# Altium schematic sheet DCDC_RPI.SchDoc(DCDC_RPI)
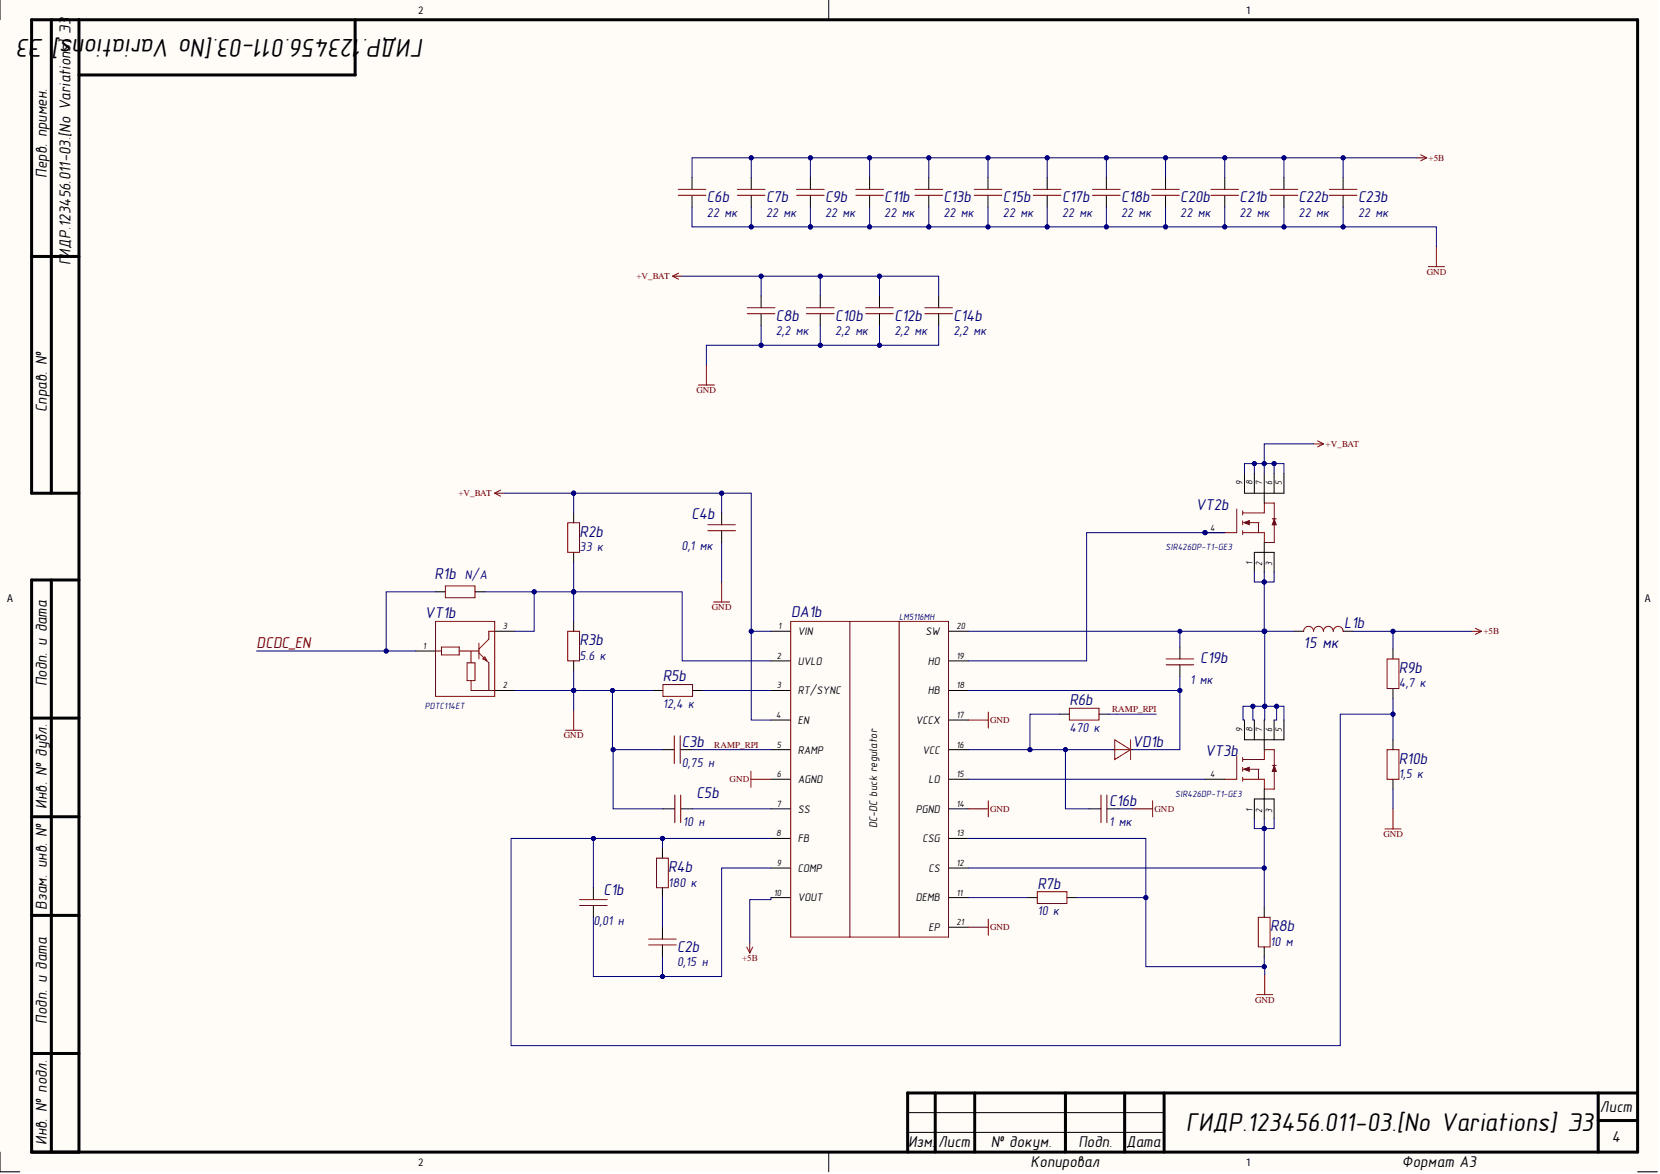
[diagram p0: DCDC_RPI.SchDoc]

## Parts
C1b  value 0,01 н  Capacity (nF): 0,01  [0603C]  (hidden comment: Capacitor)
C2b  value 0,15 н  Capacity (nF): 0,15  [0603C]  (hidden comment: Capacitor)
C3b  value 0,75 н  Capacity (nF): 0,75  [0603C]  (hidden comment: Capacitor)
C4b  value 0,1 мк  Tolerance: 0,1  [0603C]  (hidden comment: Capacitor)
C5b  value 10 н  [0603C]  (hidden comment: Capacitor)
C6b  value 22 мк  [1210C]  (hidden comment: Capacitor)
C7b  value 22 мк  [1210C]  (hidden comment: Capacitor)
C8b  Capacitor  [1206C]  (value not drawn)
C9b  value 22 мк  [1210C]  (hidden comment: Capacitor)
C10b  value 2,2 мк  [1206C]  (hidden comment: Capacitor)
C11b  value 22 мк  [1210C]  (hidden comment: Capacitor)
C12b  value 2,2 мк  [1206C]  (hidden comment: Capacitor)
C13b  value 22 мк  [1210C]  (hidden comment: Capacitor)
C14b  value 2,2 мк  [1206C]  (hidden comment: Capacitor)
C15b  value 22 мк  [1210C]  (hidden comment: Capacitor)
C16b  value 1 мк  [0603C]  (hidden comment: Capacitor)
C17b  value 22 мк  [1210C]  (hidden comment: Capacitor)
C18b  value 22 мк  [1210C]  (hidden comment: Capacitor)
C19b  value 1 мк  [0603C]  (hidden comment: Capacitor)
C20b  value 22 мк  [1210C]  (hidden comment: Capacitor)
C21b  value 22 мк  [1210C]  (hidden comment: Capacitor)
C22b  value 22 мк  [1210C]  (hidden comment: Capacitor)
C23b  value 22 мк  [1210C]  (hidden comment: Capacitor)
DA1b  LM5116MH  [HTSSOP-20]
L1b  value 15 мк  [SPRH127]  (hidden comment: CDSPRH127-150M)
R1b  Resistor  [0603R]  (value not drawn)
R2b  value 33 к  [0603R]  (hidden comment: Resistor)
R3b  value 5.6 к  [0603R]  (hidden comment: Resistor)
R4b  value 180 к  [0603R]  (hidden comment: Resistor)
R5b  value 12,4 к  [0603R]  (hidden comment: Resistor)
R6b  value 470 к  [0603R]  (hidden comment: Resistor)
R7b  value 10 к  [0603R]  (hidden comment: Resistor)
R8b  value 10 м  [1210R]  (hidden comment: Resistor)
R9b  value 4,7 к  [0603R]  (hidden comment: Resistor)
R10b  value 1,5 к  [0603R]  (hidden comment: Resistor)
VD1b  ES1D-E3/61T  [SMA]  (value not drawn)
VT1b  PDTC114ET  [SOT23-3]
VT2b  SIR426DP-T1-GE3  [PowerPAK_SO-8]
VT3b  SIR426DP-T1-GE3  [PowerPAK_SO-8]

## Nets
+5В: C6b.2 C7b.2 C9b.2 C11b.2 C13b.2 C15b.2 C17b.2 C18b.2 C20b.2 C21b.2 C22b.2 C23b.2 DA1b.10(VOUT) L1b.2 R9b.2
+V_BAT: C4b.2 C8b.2 C10b.2 C12b.2 C14b.2 DA1b.1(VIN) DA1b.4(EN) R2b.2 VT2b.5 VT2b.6 VT2b.7 VT2b.8 VT2b.9
GND: C3b.1 C4b.1 C5b.1 C6b.1 C7b.1 C8b.1 C9b.1 C10b.1 C11b.1 C12b.1 C13b.1 C14b.1 C15b.1 C16b.2 C17b.1 C18b.1 C20b.1 C21b.1 C22b.1 C23b.1 DA1b.6(AGND) DA1b.13(CSG) DA1b.14(PGND) DA1b.17(VCCX) DA1b.21(EP) R3b.1 R5b.1 R7b.2 R8b.1 R10b.1 VT1b.2
DCDC_EN: R1b.1 VT1b.1
RAMP_RPI: C3b.2 DA1b.5(RAMP) R6b.2
NetC1b_1: C1b.1 C2b.1 DA1b.9(COMP)
NetC1b_2: C1b.2 DA1b.8(FB) R4b.2 R9b.1 R10b.2
NetC2b_2: C2b.2 R4b.1
NetC5b_2: C5b.2 DA1b.7(SS)
NetC16b_1: C16b.1 DA1b.16(VCC) R6b.1 VD1b.1
NetC19b_1: C19b.1 DA1b.18(HB) VD1b.2
NetC19b_2: C19b.2 DA1b.20(SW) L1b.1 VT2b.1 VT2b.2 VT2b.3 VT3b.5 VT3b.6 VT3b.7 VT3b.8 VT3b.9
NetDA1b_2: DA1b.2(UVLO) R1b.2 R2b.1 R3b.2 VT1b.3
NetDA1b_3: DA1b.3(RT/SYNC) R5b.2
NetDA1b_11: DA1b.11(DEMB) R7b.1
NetDA1b_12: DA1b.12(CS) R8b.2 VT3b.1 VT3b.2 VT3b.3
NetDA1b_15: DA1b.15(LO) VT3b.4
NetDA1b_19: DA1b.19(HO) VT2b.4

## Library data of the parts
C1b = Capacitor_0,01n_10_50V_X7R_0603C: Конденсатор; Database Table Name: Capacitors_ceramic$; Dielectric: X7R; Library Path2: Schematic\Capacitor.SchLib; Tolerance: 0,1; Voltage (V): 50; Component Kind: Standard; Library Name: Hydrolib.DbLib; Part Number: Capacitor_0,01n_10_50V_X7R_0603C
C2b = Capacitor_0,15n_10_50V_X7R_0603C: Конденсатор; Database Table Name: Capacitors_ceramic$; Dielectric: X7R; Library Path2: Schematic\Capacitor.SchLib; Tolerance: 0,1; Voltage (V): 50; Component Kind: Standard; Library Name: Hydrolib.DbLib; Part Number: Capacitor_0,15n_10_50V_X7R_0603C
C3b = Capacitor_0,75n_10_50V_X7R_0603C: Конденсатор; Database Table Name: Capacitors_ceramic$; Dielectric: X7R; Library Path2: Schematic\Capacitor.SchLib; Tolerance: 0,1; Voltage (V): 50; Component Kind: Standard; Library Name: Hydrolib.DbLib; Part Number: Capacitor_0,75n_10_50V_X7R_0603C
C4b = Capacitor_0,1u_10_50V_X7R_0603C: Конденсатор; Capacity (nF): 100; Database Table Name: Capacitors_ceramic$; Dielectric: X7R; Library Path2: Schematic\Capacitor.SchLib; Voltage (V): 50; Component Kind: Standard; Library Name: Hydrolib.DbLib; Part Number: Capacitor_0,1u_10_50V_X7R_0603C
C5b = Capacitor_10n_10_50V_X7R_0603C: Конденсатор; Capacity (nF): 10; Database Table Name: Capacitors_ceramic$; Dielectric: X7R; Library Path2: Schematic\Capacitor.SchLib; Tolerance: 0,1; Voltage (V): 50; Component Kind: Standard; Library Name: Hydrolib.DbLib; Part Number: Capacitor_10n_10_50V_X7R_0603C
C6b = Capacitor_22u_10_25V_X7R_1210C: Конденсатор; Capacity (nF): 22000; Database Table Name: Capacitors_ceramic$; Dielectric: X7R; Library Path2: Schematic\Capacitor.SchLib; Tolerance: 0,1; Value: 22 мк; Voltage (V): 25; Component Kind: Standard; Library Name: Hydrolib.DbLib; Part Number: Capacitor_22u_10_25V_X7R_1210C
C7b C9b C11b C13b C15b C17b C18b C20b C21b C22b C23b = Capacitor_22u_10_25V_X7R_1210C: Конденсатор; Capacity (nF): 22000; Database Table Name: Capacitors_ceramic$; Dielectric: X7R; Library Path2: Schematic\Capacitor.SchLib; Tolerance: 0,1; Voltage (V): 25; Component Kind: Standard; Library Name: Hydrolib.DbLib; Part Number: Capacitor_22u_10_25V_X7R_1210C
C8b = Capacitor_2,2u_10_50V_X7R_1206C: Конденсатор; Capacity (nF): 2200; Database Table Name: Capacitors_ceramic$; Dielectric: X7R; Library Path2: Schematic\Capacitor.SchLib; Tolerance: 0,1; Value: 2,2 мк; Voltage (V): 50; Component Kind: Standard; Library Name: Hydrolib.DbLib; Part Number: Capacitor_2,2u_10_50V_X7R_1206C
C10b C12b C14b = Capacitor_2,2u_10_50V_X7R_1206C: Конденсатор; Capacity (nF): 2200; Database Table Name: Capacitors_ceramic$; Dielectric: X7R; Library Path2: Schematic\Capacitor.SchLib; Tolerance: 0,1; Voltage (V): 50; Component Kind: Standard; Library Name: Hydrolib.DbLib; Part Number: Capacitor_2,2u_10_50V_X7R_1206C
C16b C19b = Capacitor_1u_10_50V_X7R_0603C: Конденсатор; Capacity (nF): 1000; Database Table Name: Capacitors_ceramic$; Dielectric: X7R; Library Path2: Schematic\Capacitor.SchLib; Tolerance: 0,1; Voltage (V): 50; Component Kind: Standard; Library Name: Hydrolib.DbLib; Part Number: Capacitor_1u_10_50V_X7R_0603C
DA1b = LM5116MH: dc-dc понижающий adj 6-100v; Manufacturer_Part_Number: LM5116MH/NOPB; Database Table Name: Chips$; Component Kind: Standard; Library Name: Hydrolib.DbLib; Part Number: LM5116MH
L1b = CDSPRH127-150M: Индуктивность на 15 мкГн, номинальный ток 8,4 А; Current (A): 8,4; Database Table Name: Inductance$; Inductance (μH): 15; Component Kind: Standard; Library Name: Hydrolib.DbLib; Part Number: CDSPRH127-150M
R1b = res_0603_N/A: посадочное место 0603 под перемычку; Database Table Name: Resistors$; Tolerance: 1%; Component Kind: Standard; Library Name: Hydrolib.DbLib; Part Number: res_0603_N/A; Value: N/A
R2b = Resistor_33k_1_0603: Резистор номиналом 33 кОм и точностью 1%; Database Table Name: Resistors$; Component Kind: Standard; Library Name: Hydrolib.DbLib; Part Number: Resistor_33k_1_0603; Tolerance: 1%
R3b = Resistor_2.7k_1_0603: Резистор номиналом 2,7 кОм и точностью 1%; Database Table Name: Resistors$; Component Kind: Standard; Library Name: Hydrolib.DbLib; Part Number: Resistor_2.7k_1_0603; Tolerance: 1%
R4b = Resistor_180k_1_0603: Резистор номиналом 180 кОм и точностью 1%; Database Table Name: Resistors$; Component Kind: Standard; Library Name: Hydrolib.DbLib; Part Number: Resistor_180k_1_0603; Tolerance: 1%
R5b = Resistor_12.4k_1_0603: Резистор номиналом 12,4 кОм и точностью 1%; Database Table Name: Resistors$; Component Kind: Standard; Library Name: Hydrolib.DbLib; Part Number: Resistor_12.4k_1_0603; Tolerance: 1%
R6b = Resistor_470k_1_0603: Резистор номиналом 470 кОм и точностью 1%; Database Table Name: Resistors$; Component Kind: Standard; Library Name: Hydrolib.DbLib; Part Number: Resistor_470k_1_0603; Tolerance: 1%
R7b = Resistor_10k_1_0603: Резистор номиналом 10 кОм и точностью 1%; Database Table Name: Resistors$; Component Kind: Standard; Library Name: Hydrolib.DbLib; Part Number: Resistor_10k_1_0603; Tolerance: 1%
R8b = RL1210FR-070R01L: Резистор номиналом 10 мОм, точностью 1%, 0.5Вт; Database Table Name: Resistors$; Component Kind: Standard; Library Name: Hydrolib.DbLib; Part Number: RL1210FR-070R01L; Tolerance: 1%
R9b = Resistor_4.7k_1_0603: Резистор номиналом 4,7 кОм и точностью 1%; Database Table Name: Resistors$; Component Kind: Standard; Library Name: Hydrolib.DbLib; Part Number: Resistor_4.7k_1_0603; Tolerance: 1%
R10b = Resistor_1.5k_1_0603: Резистор номиналом 1,5 кОм и точностью 1%; Database Table Name: Resistors$; Component Kind: Standard; Library Name: Hydrolib.DbLib; Part Number: Resistor_1.5k_1_0603; Tolerance: 1%
VD1b = ES1D-E3/61T: Диод 1A 200V; Current (A): 1; Database Table Name: Rectifier Diodes$; Repetitive peak reverse voltage (Vrrm): 200; Component Kind: Standard; Library Name: Hydrolib.DbLib; Part Number: ES1D-E3/61T
VT1b = PDTC114ET: цифровой npn 50в 100мА; Database Table Name: Transistors$; Ток макс#: 0, 1 А; Component Kind: Standard; Library Name: Hydrolib.DbLib; Part Number: PDTC114ET; Напряжение С-И/К-Э: 50 В
VT2b VT3b = SIR426DP-T1-GE3: Транзистор полевой MOSFET N-канальный 40В 41.7Вт; Database Table Name: Transistors$; Component Kind: Standard; Library Name: Hydrolib.DbLib; Part Number: SIR426DP-T1-GE3; Напряжение С-И/К-Э: 40 В
